# Altium schematic sheet led_matrix.SchDoc
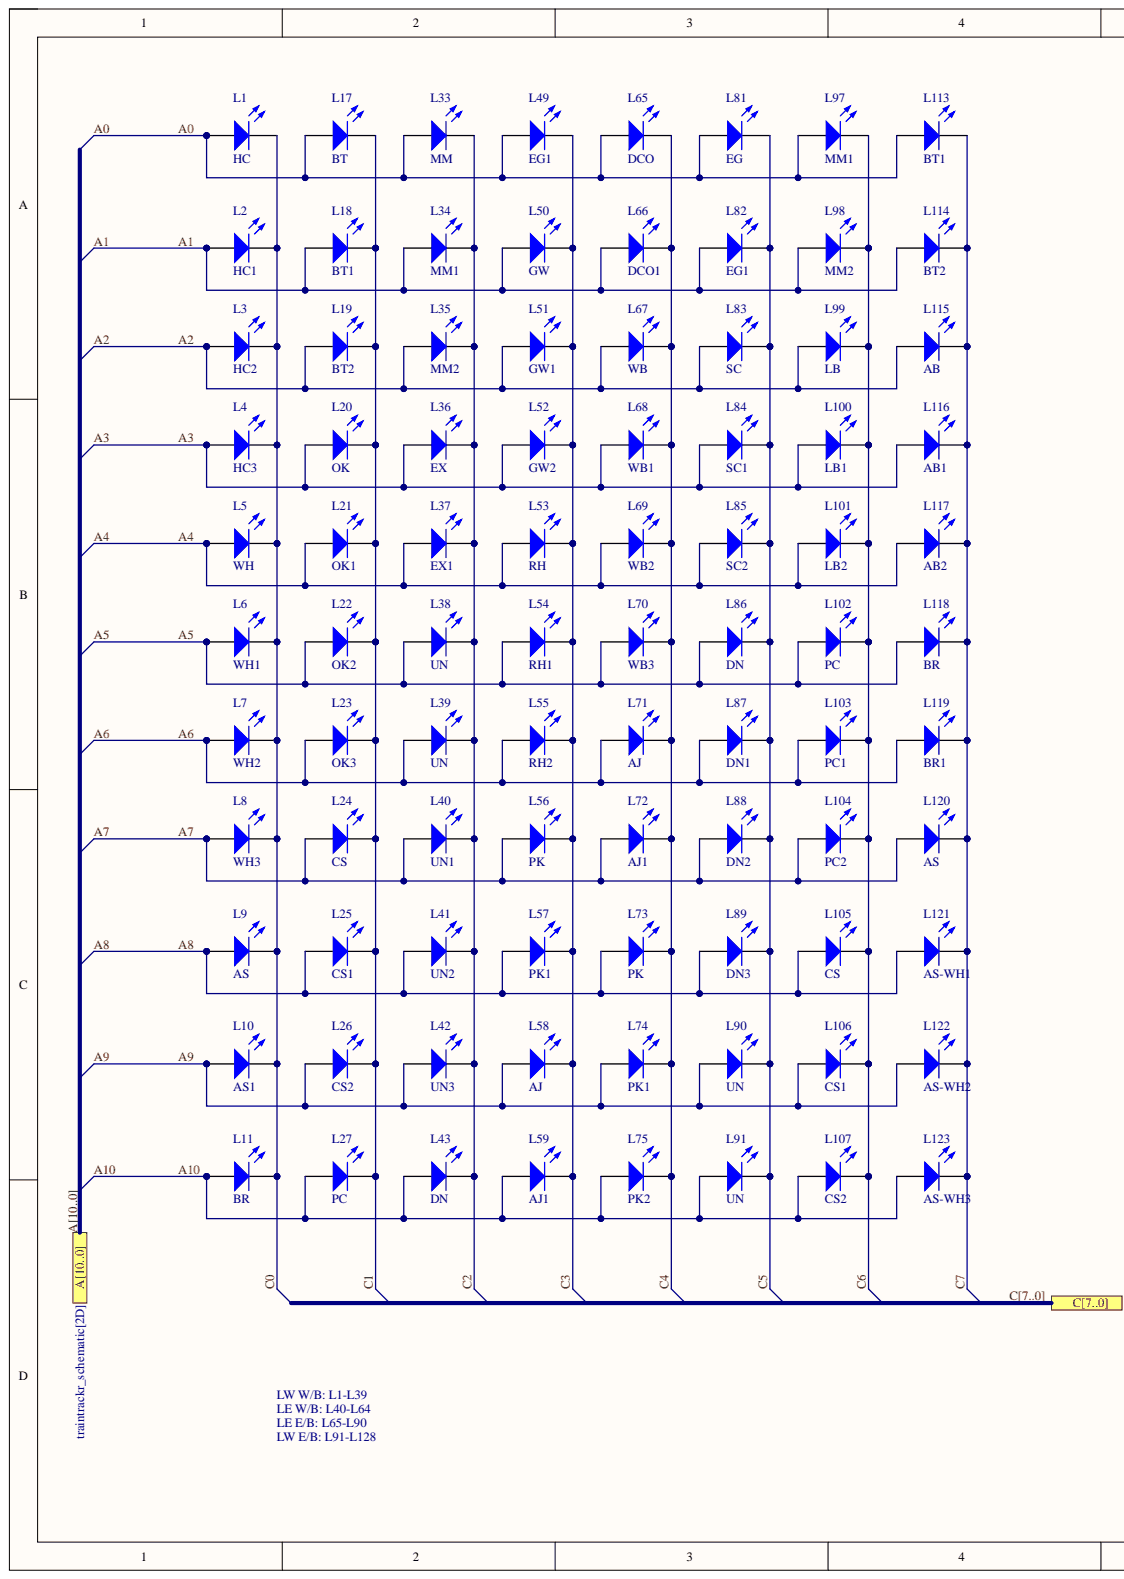
[diagram p0: led_matrix.SchDoc, left]
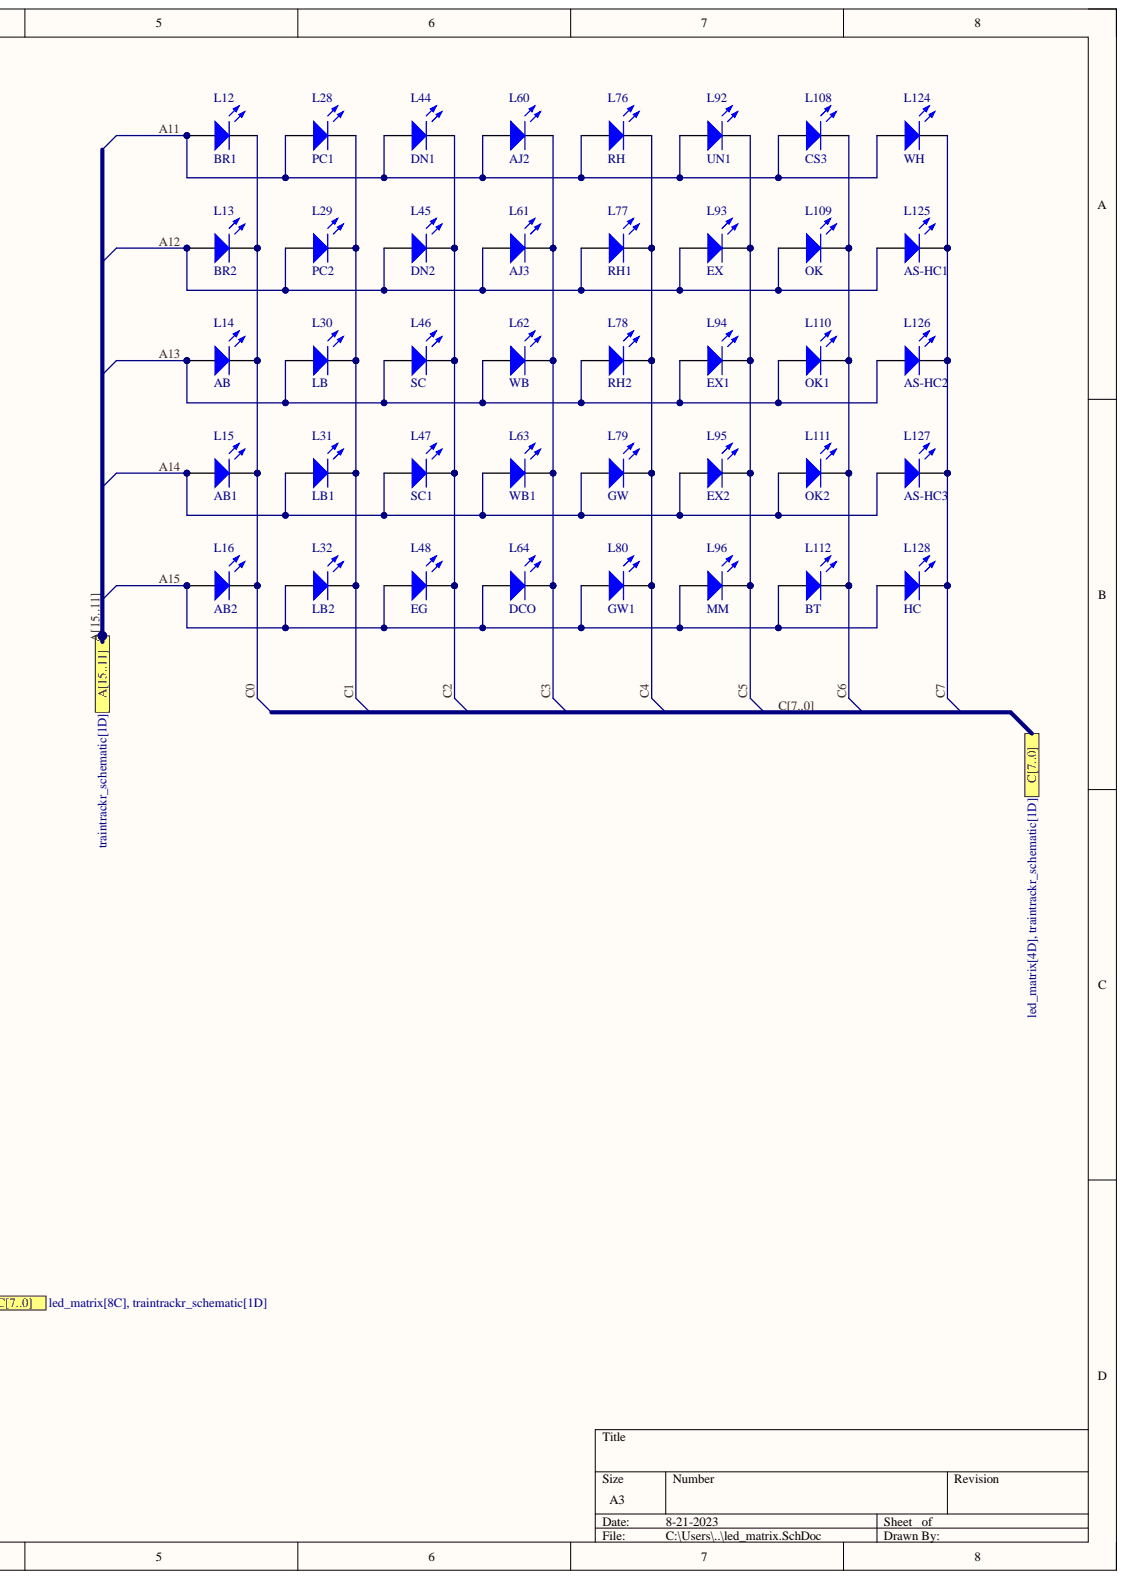
[diagram p1: led_matrix.SchDoc, right]

## Parts
L1  HC  [PCBComponent_1]
L2  HC1  [PCBComponent_1]
L3  HC2  [PCBComponent_1]
L4  HC3  [PCBComponent_1]
L5  WH  [PCBComponent_1]
L6  WH1  [PCBComponent_1]
L7  WH2  [PCBComponent_1]
L8  WH3  [PCBComponent_1]
L9  AS  [PCBComponent_1]
L10  AS1  [PCBComponent_1]
L11  BR  [PCBComponent_1]
L12  BR1  [PCBComponent_1]
L13  BR2  [PCBComponent_1]
L14  AB  [PCBComponent_1]
L15  AB1  [PCBComponent_1]
L16  AB2  [PCBComponent_1]
L17  BT  [PCBComponent_1]
L18  BT1  [PCBComponent_1]
L19  BT2  [PCBComponent_1]
L20  OK  [PCBComponent_1]
L21  OK1  [PCBComponent_1]
L22  OK2  [PCBComponent_1]
L23  OK3  [PCBComponent_1]
L24  CS  [PCBComponent_1]
L25  CS1  [PCBComponent_1]
L26  CS2  [PCBComponent_1]
L27  PC  [PCBComponent_1]
L28  PC1  [PCBComponent_1]
L29  PC2  [PCBComponent_1]
L30  LB  [PCBComponent_1]
L31  LB1  [PCBComponent_1]
L32  LB2  [PCBComponent_1]
L33  MM  [PCBComponent_1]
L34  MM1  [PCBComponent_1]
L35  MM2  [PCBComponent_1]
L36  EX  [PCBComponent_1]
L37  EX1  [PCBComponent_1]
L38  UN  [PCBComponent_1]
L39  UN  [PCBComponent_1]
L40  UN1  [PCBComponent_1]
L41  UN2  [PCBComponent_1]
L42  UN3  [PCBComponent_1]
L43  DN  [PCBComponent_1]
L44  DN1  [PCBComponent_1]
L45  DN2  [PCBComponent_1]
L46  SC  [PCBComponent_1]
L47  SC1  [PCBComponent_1]
L48  EG  [PCBComponent_1]
L49  EG1  [PCBComponent_1]
L50  GW  [PCBComponent_1]
L51  GW1  [PCBComponent_1]
L52  GW2  [PCBComponent_1]
L53  RH  [PCBComponent_1]
L54  RH1  [PCBComponent_1]
L55  RH2  [PCBComponent_1]
L56  PK  [PCBComponent_1]
L57  PK1  [PCBComponent_1]
L58  AJ  [PCBComponent_1]
L59  AJ1  [PCBComponent_1]
L60  AJ2  [PCBComponent_1]
L61  AJ3  [PCBComponent_1]
L62  WB  [PCBComponent_1]
L63  WB1  [PCBComponent_1]
L64  DCO  [PCBComponent_1]
L65  DCO  [PCBComponent_1]
L66  DCO1  [PCBComponent_1]
L67  WB  [PCBComponent_1]
L68  WB1  [PCBComponent_1]
L69  WB2  [PCBComponent_1]
L70  WB3  [PCBComponent_1]
L71  AJ  [PCBComponent_1]
L72  AJ1  [PCBComponent_1]
L73  PK  [PCBComponent_1]
L74  PK1  [PCBComponent_1]
L75  PK2  [PCBComponent_1]
L76  RH  [PCBComponent_1]
L77  RH1  [PCBComponent_1]
L78  RH2  [PCBComponent_1]
L79  GW  [PCBComponent_1]
L80  GW1  [PCBComponent_1]
L81  EG  [PCBComponent_1]
L82  EG1  [PCBComponent_1]
L83  SC  [PCBComponent_1]
L84  SC1  [PCBComponent_1]
L85  SC2  [PCBComponent_1]
L86  DN  [PCBComponent_1]
L87  DN1  [PCBComponent_1]
L88  DN2  [PCBComponent_1]
L89  DN3  [PCBComponent_1]
L90  UN  [PCBComponent_1]
L91  UN  [PCBComponent_1]
L92  UN1  [PCBComponent_1]
L93  EX  [PCBComponent_1]
L94  EX1  [PCBComponent_1]
L95  EX2  [PCBComponent_1]
L96  MM  [PCBComponent_1]
L97  MM1  [PCBComponent_1]
L98  MM2  [PCBComponent_1]
L99  LB  [PCBComponent_1]
L100  LB1  [PCBComponent_1]
L101  LB2  [PCBComponent_1]
L102  PC  [PCBComponent_1]
L103  PC1  [PCBComponent_1]
L104  PC2  [PCBComponent_1]
L105  CS  [PCBComponent_1]
L106  CS1  [PCBComponent_1]
L107  CS2  [PCBComponent_1]
L108  CS3  [PCBComponent_1]
L109  OK  [PCBComponent_1]
L110  OK1  [PCBComponent_1]
L111  OK2  [PCBComponent_1]
L112  BT  [PCBComponent_1]
L113  BT1  [PCBComponent_1]
L114  BT2  [PCBComponent_1]
L115  AB  [PCBComponent_1]
L116  AB1  [PCBComponent_1]
L117  AB2  [PCBComponent_1]
L118  BR  [PCBComponent_1]
L119  BR1  [PCBComponent_1]
L120  AS  [PCBComponent_1]
L121  AS-WH1  [PCBComponent_1]
L122  AS-WH2  [PCBComponent_1]
L123  AS-WH3  [PCBComponent_1]
L124  WH  [PCBComponent_1]
L125  AS-HC1  [PCBComponent_1]
L126  AS-HC2  [PCBComponent_1]
L127  AS-HC3  [PCBComponent_1]
L128  HC  [PCBComponent_1]

## Ports
A0, A1, A2, A3, A4, A5, A6, A7, A8, A9, A10, A11, A12, A13, A14, A15, A[10..0], A[15..11], C0, C1, C2, C3, C4, C5, C6, C7, C[7..0]

## Nets
A0: L1.1 L17.1 L33.1 L49.1 L65.1 L81.1 L97.1 L113.1 ; port A[10..0]  [also labelled A]
A1: L2.1 L18.1 L34.1 L50.1 L66.1 L82.1 L98.1 L114.1 ; port A[10..0]  [also labelled A]
A2: L3.1 L19.1 L35.1 L51.1 L67.1 L83.1 L99.1 L115.1 ; port A[10..0]  [also labelled A]
A3: L4.1 L20.1 L36.1 L52.1 L68.1 L84.1 L100.1 L116.1 ; port A[10..0]  [also labelled A]
A4: L5.1 L21.1 L37.1 L53.1 L69.1 L85.1 L101.1 L117.1 ; port A[10..0]  [also labelled A]
A5: L6.1 L22.1 L38.1 L54.1 L70.1 L86.1 L102.1 L118.1 ; port A[10..0]  [also labelled A]
A6: L7.1 L23.1 L39.1 L55.1 L71.1 L87.1 L103.1 L119.1 ; port A[10..0]  [also labelled A]
A7: L8.1 L24.1 L40.1 L56.1 L72.1 L88.1 L104.1 L120.1 ; port A[10..0]  [also labelled A]
A8: L9.1 L25.1 L41.1 L57.1 L73.1 L89.1 L105.1 L121.1 ; port A[10..0]  [also labelled A]
A9: L10.1 L26.1 L42.1 L58.1 L74.1 L90.1 L106.1 L122.1 ; port A[10..0]  [also labelled A]
A10: L11.1 L27.1 L43.1 L59.1 L75.1 L91.1 L107.1 L123.1 ; port A[10..0]  [also labelled A]
A11: L12.1 L28.1 L44.1 L60.1 L76.1 L92.1 L108.1 L124.1 ; port A[15..11]  [also labelled A]
A12: L13.1 L29.1 L45.1 L61.1 L77.1 L93.1 L109.1 L125.1 ; port A[15..11]  [also labelled A]
A13: L14.1 L30.1 L46.1 L62.1 L78.1 L94.1 L110.1 L126.1 ; port A[15..11]  [also labelled A]
A14: L15.1 L31.1 L47.1 L63.1 L79.1 L95.1 L111.1 L127.1 ; port A[15..11]  [also labelled A]
A15: L16.1 L32.1 L48.1 L64.1 L80.1 L96.1 L112.1 L128.1 ; port A[15..11]  [also labelled A]
C0: L1.2 L2.2 L3.2 L4.2 L5.2 L6.2 L7.2 L8.2 L9.2 L10.2 L11.2 L12.2 L13.2 L14.2 L15.2 L16.2 ; port C[7..0]  [also labelled C]
C1: L17.2 L18.2 L19.2 L20.2 L21.2 L22.2 L23.2 L24.2 L25.2 L26.2 L27.2 L28.2 L29.2 L30.2 L31.2 L32.2 ; port C[7..0]  [also labelled C]
C2: L33.2 L34.2 L35.2 L36.2 L37.2 L38.2 L39.2 L40.2 L41.2 L42.2 L43.2 L44.2 L45.2 L46.2 L47.2 L48.2 ; port C[7..0]  [also labelled C]
C3: L49.2 L50.2 L51.2 L52.2 L53.2 L54.2 L55.2 L56.2 L57.2 L58.2 L59.2 L60.2 L61.2 L62.2 L63.2 L64.2 ; port C[7..0]  [also labelled C]
C4: L65.2 L66.2 L67.2 L68.2 L69.2 L70.2 L71.2 L72.2 L73.2 L74.2 L75.2 L76.2 L77.2 L78.2 L79.2 L80.2 ; port C[7..0]  [also labelled C]
C5: L81.2 L82.2 L83.2 L84.2 L85.2 L86.2 L87.2 L88.2 L89.2 L90.2 L91.2 L92.2 L93.2 L94.2 L95.2 L96.2 ; port C[7..0]  [also labelled C]
C6: L97.2 L98.2 L99.2 L100.2 L101.2 L102.2 L103.2 L104.2 L105.2 L106.2 L107.2 L108.2 L109.2 L110.2 L111.2 L112.2 ; port C[7..0]  [also labelled C]
C7: L113.2 L114.2 L115.2 L116.2 L117.2 L118.2 L119.2 L120.2 L121.2 L122.2 L123.2 L124.2 L125.2 L126.2 L127.2 L128.2 ; port C[7..0]  [also labelled C]
A[10..0]: ; port A[10..0]  [also labelled A]
A[15..11]: ; port A[15..11]  [also labelled A]

## Library data of the parts
L1-L128 = LED1: Typical RED GaAs LED; Component Kind: Standard; LatestRevisionDate: 08-Jul-2005; LatestRevisionNote: Mech Layer 1 removed.; Library Name: Miscellaneous Devices.IntLib; PackageDescription: LED; 2 Leads; PackageReference: LED-1; Published: 8-Jun-2000; Simulation: LED1; Publisher: Altium Limited
